# Altium schematic sheet Memory.SchDoc(Memory)
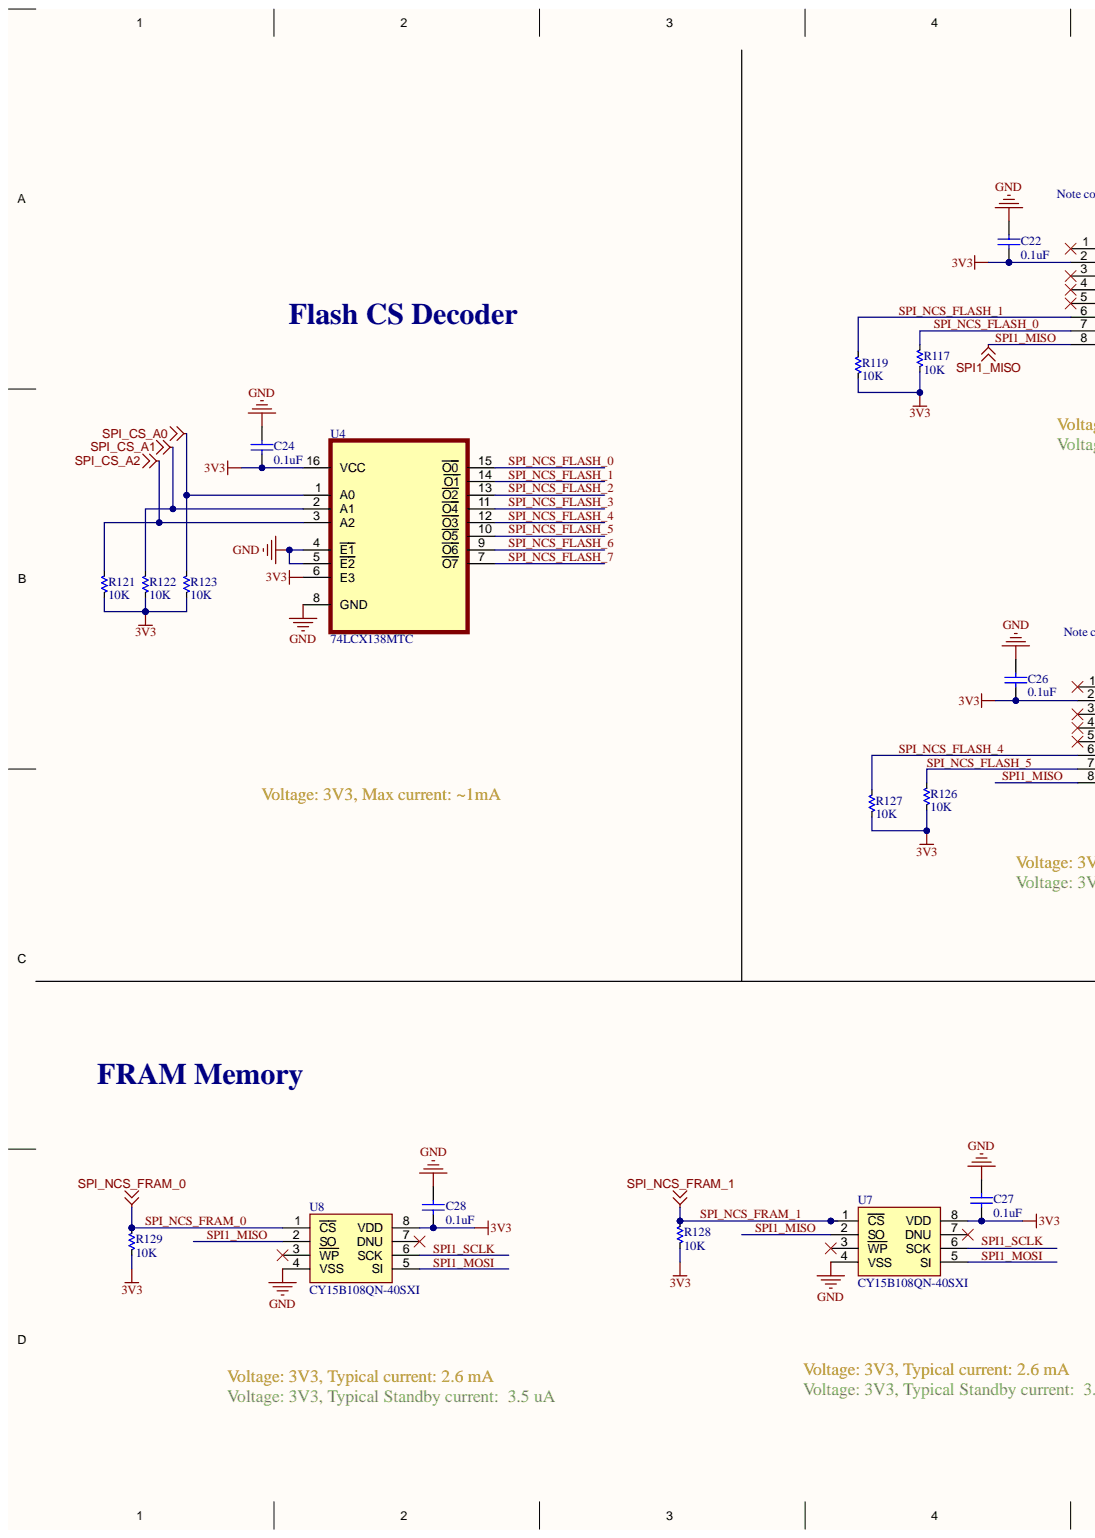
[diagram p0: Memory.SchDoc, left]
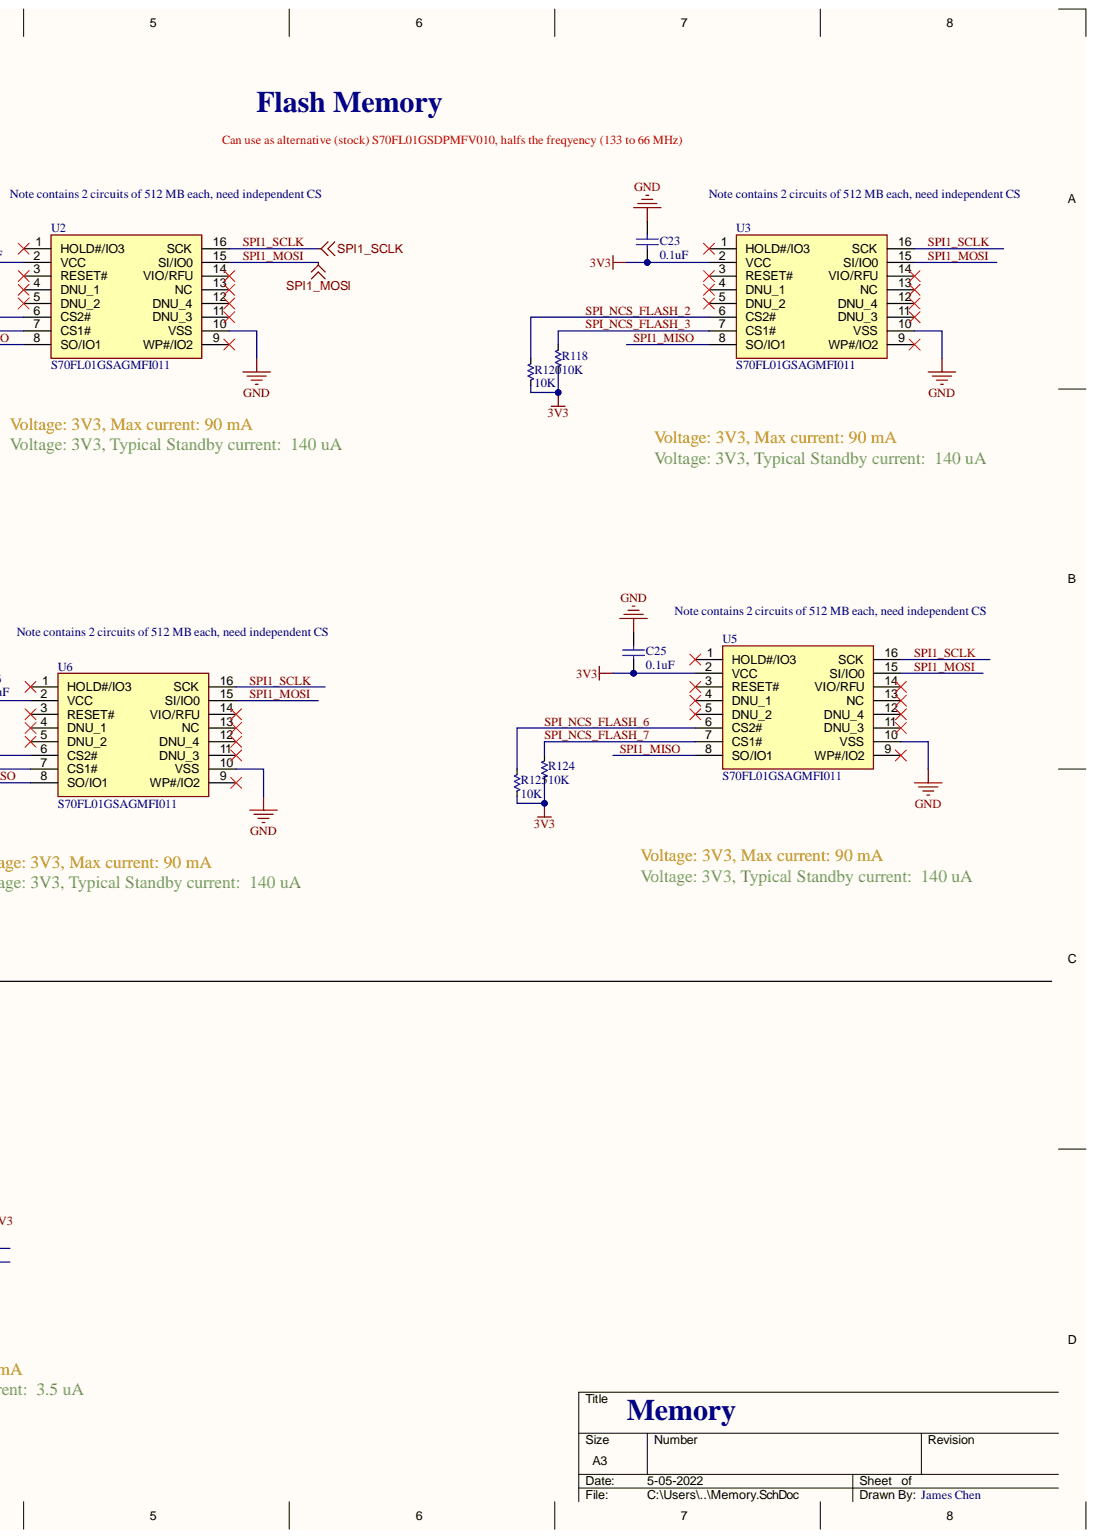
[diagram p1: Memory.SchDoc, right]

## Parts
C22  0.1uF  [0603]
C23  0.1uF  [0603]
C24  0.1uF  [0603]
C25  0.1uF  [0603]
C26  0.1uF  [0603]
C27  0.1uF  [0603]
C28  0.1uF  [0603]
R117  10K  [0603]
R118  10K  [0603]
R119  10K  [0603]
R120  10K  [0603]
R121  10K  [0603]
R122  10K  [0603]
R123  10K  [0603]
R124  10K  [0603]
R125  10K  [0603]
R126  10K  [0603]
R127  10K  [0603]
R128  10K  [0603]
R129  10K  [0603]
U2  S70FL01GSAGMFI011  [U-S70FL01GSAGMFI011]
U3  S70FL01GSAGMFI011  [U-S70FL01GSAGMFI011]
U4  74LCX138MTC  [U-74LCX138MTC]
U5  S70FL01GSAGMFI011  [U-S70FL01GSAGMFI011]
U6  S70FL01GSAGMFI011  [U-S70FL01GSAGMFI011]
U7  CY15B108QN-40SXI  [U-CY15B108QN-40SXI]
U8  CY15B108QN-40SXI  [U-CY15B108QN-40SXI]

## Nets
3V3: C22.1 C23.1 C24.1 C25.1 C26.1 C27.1 C28.1 R117.1 R118.1 R119.1 R120.1 R121.1 R122.1 R123.1 R124.1 R125.1 R126.1 R127.1 R128.1 R129.1 U2.2(VCC) U3.2(VCC) U4.6(E3) U4.16(VCC) U5.2(VCC) U6.2(VCC) U7.8(VDD) U8.8(VDD)
GND: C22.2 C23.2 C24.2 C25.2 C26.2 C27.2 C28.2 U2.10(VSS) U3.10(VSS) U4.4(E1) U4.5(E2) U4.8(GND) U5.10(VSS) U6.10(VSS) U7.4(VSS) U8.4(VSS)
SPI_CS_A0: R123.2 U4.1(A0)
SPI_CS_A1: R122.2 U4.2(A1)
SPI_CS_A2: R121.2 U4.3(A2)
SPI1_MISO: U2.8(SO/IO1) U3.8(SO/IO1) U5.8(SO/IO1) U6.8(SO/IO1) U7.2(SO) U8.2(SO)
SPI1_MOSI: U2.15(SI/IO0) U3.15(SI/IO0) U5.15(SI/IO0) U6.15(SI/IO0) U7.5(SI) U8.5(SI)
SPI1_SCLK: U2.16(SCK) U3.16(SCK) U5.16(SCK) U6.16(SCK) U7.6(SCK) U8.6(SCK)
SPI_NCS_FLASH_0: R117.2 U2.7(CS1#) U4.15(O0)
SPI_NCS_FLASH_1: R119.2 U2.6(CS2#) U4.14(O1)
SPI_NCS_FLASH_2: R120.2 U3.6(CS2#) U4.13(O2)
SPI_NCS_FLASH_3: R118.2 U3.7(CS1#) U4.11(O4)
SPI_NCS_FLASH_4: R127.2 U4.12(O3) U6.6(CS2#)
SPI_NCS_FLASH_5: R126.2 U4.10(O5) U6.7(CS1#)
SPI_NCS_FLASH_6: R125.2 U4.9(O6) U5.6(CS2#)
SPI_NCS_FLASH_7: R124.2 U4.7(O7) U5.7(CS1#)
SPI_NCS_FRAM_0: R129.2 U8.1(CS)
SPI_NCS_FRAM_1: R128.2 U7.1(CS)

Not connected: U2 1(HOLD#/IO3) 3(RESET#) 4(DNU_1) 5(DNU_2) 9(WP#/IO2) 11(DNU_3) 12(DNU_4) 13(NC) 14(VIO/RFU); U3 1(HOLD#/IO3) 3(RESET#) 4(DNU_1) 5(DNU_2) 9(WP#/IO2) 11(DNU_3) 12(DNU_4) 13(NC) 14(VIO/RFU); U5 1(HOLD#/IO3) 3(RESET#) 4(DNU_1) 5(DNU_2) 9(WP#/IO2) 11(DNU_3) 12(DNU_4) 13(NC) 14(VIO/RFU); U6 1(HOLD#/IO3) 3(RESET#) 4(DNU_1) 5(DNU_2) 9(WP#/IO2) 11(DNU_3) 12(DNU_4) 13(NC) 14(VIO/RFU); U7 3(WP) 7(DNU); U8 3(WP) 7(DNU)

## Library data of the parts
C22-C28 = CC-104-K-25V-X7R-0603: 0.1 µF ±10% 25V Ceramic Capacitor X7R 0603 (1608 Metric); Manufacturer: Samsung Electro-Mechanics; Manufacturer Part #: CL10B104KA8NNNC; Component Kind: Standard; ComponentLink1description: Datasheet; ComponentLink1URL: https://ds.yuden.co.jp/TYCOMPAS/ut/detail?pn=TMK105BJ104KV-F%20%20&u=M; ComponentLink2description: Manufacturer Website; ComponentLink2URL: https://www.yuden.co.jp/or/; Distributor: Digikey; Distributor Part #: 1276-1006-1-ND; Part Description: 0.1 µF ±10% 25V Ceramic Capacitor X7R 0603 (1608 Metric); Value: 0.1uF; Library Name: OBC Development Board.SchLib
R117-R129 = RT-10K0-F-1/16W-0603: 10 kOhms ±0.1% 0.063W, 1/16W Chip Resistor 0603 (1608 Metric) - Thin Film; Manufacturer: TE Connectivity Passive Product; Manufacturer Part #: CPF0603B10KE; Component Kind: Standard; ComponentLink1description: Datasheet; ComponentLink1URL: https://www.vishay.com/docs/20035/dcrcwe3.pdf; ComponentLink2description: Manufacturer Website; ComponentLink2URL: https://www.vishay.com/; Distributor: Digikey; Distributor Part #: A119886CT-ND; Part Description: 10 kOhms ±0.1% 0.063W, 1/16W Chip Resistor 0603 (1608 Metric) - Thin Film; Value: 10K; Library Name: OBC Development Board.SchLib
U2 U3 U5 U6 = U-S70FL01GSAGMFI011: IC FLASH 1GBIT SPI/QUAD 16SOIC; Manufacturer: Cypress Semiconductor; Component Kind: Standard; Distributor: Digikey; Distributor Part #: 1274-1092-ND; Manufacturer Part #: S70FL01GSAGMFI011; Library Name: OBC Development Board.SchLib
U4 = U-74LCX138MTC: IC DECODER/DEMUX 1X3:8 16TSSOP; Manufacturer: onsemi; Component Kind: Standard; Distributor: Digikey; Distributor Part #: 74LCX138MTC-ND; Manufacturer Part #: 74LCX138MTC; Library Name: OBC Development Board.SchLib
U7 U8 = U-CY15B108QN-40SXI: IC FRAM 8MBIT SPI 40MHZ 8SOIC; Manufacturer: Cypress Semiconductor; Component Kind: Standard; Distributor: Digikey; Distributor Part #: 428-4717-ND; Manufacturer Part #: CY15B108QN-40SXI; Library Name: OBC Development Board.SchLib
